# Altium schematic sheet A-Headers.SchDoc(A-Headers)
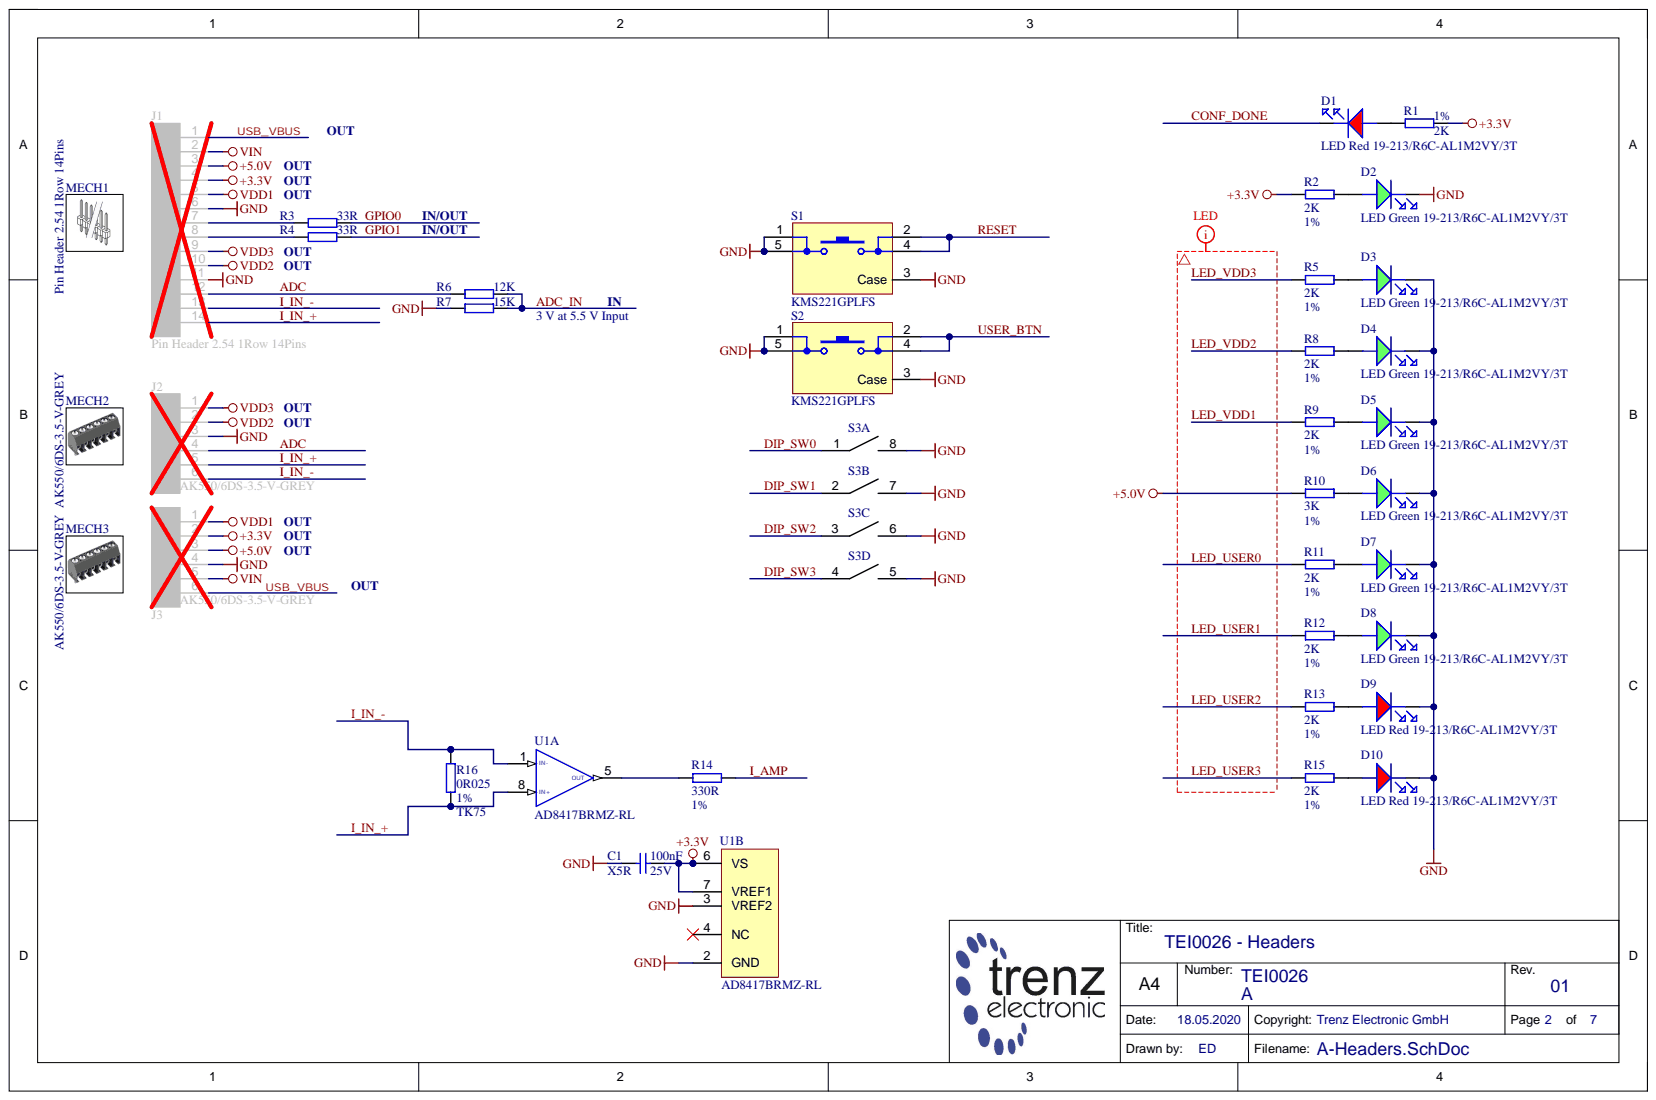
[diagram p0: A-Headers.SchDoc]

## Parts
C1  100nF  Technology: X5R  Voltage: 25V  [CAPC1005X55N]
D1  LED Red 19-213/R6C-AL1M2VY/3T  Database Table Name: LED  H-ART: 19-213/R6C-AL1M2VY/3T  [LEDC1608X55N_RED]
D2  LED Green 19-213/R6C-AL1M2VY/3T  Database Table Name: LED  [LEDC1608X55N_GREEN]
D3  LED Green 19-213/R6C-AL1M2VY/3T  Database Table Name: LED  [LEDC1608X55N_GREEN]
D4  LED Green 19-213/R6C-AL1M2VY/3T  Database Table Name: LED  [LEDC1608X55N_GREEN]
D5  LED Green 19-213/R6C-AL1M2VY/3T  Database Table Name: LED  [LEDC1608X55N_GREEN]
D6  LED Green 19-213/R6C-AL1M2VY/3T  Database Table Name: LED  [LEDC1608X55N_GREEN]
D7  LED Green 19-213/R6C-AL1M2VY/3T  Database Table Name: LED  [LEDC1608X55N_GREEN]
D8  LED Green 19-213/R6C-AL1M2VY/3T  Database Table Name: LED  [LEDC1608X55N_GREEN]
D9  LED Red 19-213/R6C-AL1M2VY/3T  Database Table Name: LED  H-ART: 19-213/R6C-AL1M2VY/3T  [LEDC1608X55N_RED]
D10  LED Red 19-213/R6C-AL1M2VY/3T  Database Table Name: LED  H-ART: 19-213/R6C-AL1M2VY/3T  [LEDC1608X55N_RED]
J1  Pin Header 2.54 1Row 14Pins  [STIFTLEISTE2_54 OG 1R-14]
J2  AK550/6DS-3.5-V-GREY  [AK550-6DS-3.5-V-GRAU]
J3  AK550/6DS-3.5-V-GREY  [AK550-6DS-3.5-V-GRAU]
MECH1  Pin Header 2.54 1Row 14Pins  (mechanical)
MECH2  AK550/6DS-3.5-V-GREY  (mechanical)
MECH3  AK550/6DS-3.5-V-GREY  (mechanical)
R1  2K  Tolerance: 1%  [RESC1005X40N]
R2  2K  Tolerance: 1%  [RESC1005X40N]
R3  33R  [RESC1005X40N]
R4  33R  [RESC1005X40N]
R5  2K  Tolerance: 1%  [RESC1005X40N]
R6  12K  [RESC1005X40N]
R7  15K  [RESC1005X40N]
R8  2K  Tolerance: 1%  [RESC1005X40N]
R9  2K  Tolerance: 1%  [RESC1005X40N]
R10  3K  Tolerance: 1%  [RESC1005X40N]
R11  2K  Tolerance: 1%  [RESC1005X40N]
R12  2K  Tolerance: 1%  [RESC1005X40N]
R13  2K  Tolerance: 1%  [RESC1005X40N]
R14  330R  Tolerance: 1%  [RESC1005X40N]
R15  2K  Tolerance: 1%  [RESC1005X40N]
R16  0R025  Technology: TK75  Tolerance: 1%  [RESC5025X88L50N]
S1  KMS221GPLFS  [KMS2xxGPLFS]
S2  KMS221GPLFS  [KMS2xxGPLFS]
S3  CHS-04TA  [CHS-04TA]  (value not drawn)  (parts A,B,C,D)
U1  AD8417BRMZ-RL  [SOP65P490X110-8N]  (parts A,B)

## Nets
+3.3V: C1.1 J1.4 J3.2 R1.2 R2.2 U1.6(VS) U1.7(VREF1)
+5.0V: J1.3 J3.3 R10.1
GND: C1.2 D2.K D3.K D4.K D5.K D6.K D7.K D8.K D9.K D10.K J1.6 J1.11 J2.3 J3.4 R7.1 S1.1 S1.3(Case) S1.5 S2.1 S2.3(Case) S2.5 S3.5 S3.6 S3.7 S3.8 U1.2(GND) U1.3(VREF2)
VDD1: J1.5 J3.1
VDD2: J1.10 J2.2
VDD3: J1.9 J2.1
VIN: J1.2 J3.5
ADC: J1.12 J2.4 R6.1
ADC_IN: R6.2 R7.2
CONF_DONE: D1.K
DIP_SW0: S3.1
DIP_SW1: S3.2
DIP_SW2: S3.3
DIP_SW3: S3.4
GPIO0: R3.2
GPIO1: R4.2
I_AMP: R14.2
I_IN_+: J1.14 J2.5 R16.2 U1.8(IN+)
I_IN_-: J1.13 J2.6 R16.1 U1.1(IN-)
LED_USER0: R11.1
LED_USER1: R12.1
LED_USER2: R13.1
LED_USER3: R15.1
LED_VDD1: R9.1
LED_VDD2: R8.1
LED_VDD3: R5.1
RESET: S1.2 S1.4
USB_VBUS: J1.1 J3.6
USER_BTN: S2.2 S2.4
NetD1_A: D1.A R1.1
NetD2_A: D2.A R2.1
NetD3_A: D3.A R5.2
NetD4_A: D4.A R8.2
NetD5_A: D5.A R9.2
NetD6_A: D6.A R10.2
NetD7_A: D7.A R11.2
NetD8_A: D8.A R12.2
NetD9_A: D9.A R13.2
NetD10_A: D10.A R15.2
NetJ1_7: J1.7 R3.1
NetJ1_8: J1.8 R4.1
NetR14_1: R14.1 U1.5(OUT)

Not connected: U1 4(NC)

## Library data of the parts
C1 = 22472: KON 100nF 25V X5R ±10% SMD BF 1005 (0402); Database Table Name: C_1005_0402; Mixed: SMT; Preferred: Yes; Temperature-Range: -55/+85; Value: 100n; AN: 22472; Case: 1005 (0402); Component Kind: Standard; Library Name: TE.DbLib
D1 D9 D10 = 28261: Chip LED 0603 Briliant Red; Mixed: SMT; AN: 28261; Case: 1608 (0603); Component Kind: Standard; Library Name: TE.DbLib; HelpURL: b:\AD\adlib\datasheet\19-213-R6C\
D2-D8 = 28262: Chip LED 0603 Briliant Green; H-ART: 19-213/G6C-BM1N2/DT; Mixed: SMT; Temperature-Range: -40/+85 (Abs); AN: 28262; Case: 1608 (0603); Component Kind: Standard; Library Name: TE.DbLib; HelpURL: b:\AD\adlib\datasheet\19-213-G6C-BM1\
J1 = 27961: Stiftleiste gerade 1-reihig, 14pol.; Database Table Name: CON_HEADER; Mixed: THT; AN: 27961; Component Kind: Standard; Library Name: TE.DbLib; HelpURL: b:\AD\adlib\datasheet\W+P_943_944_945\
J2 J3 = 30193: Database Table Name: CON_PWR; H-ART: 50550060121G; Mixed: THT; Temperature-Range: -40/+105; AN: 30193; Component Kind: Standard; Description: AK550/6DS-3.5-V-GREY; Library Name: TE.DbLib; Storage-Temperature: -40/+105; HelpURL: b:\AD\adlib\datasheet\PTR\AK550xxDS_3,5_V\
MECH1 = 30273: Stiftleiste gerade 1-reihig, 14pol.; Database Table Name: CON_HEADER; Extra: 2,54mm RM, 11,3mm Stiftlänge; Mixed: THT; Trenz-Article-Number: 27961; AN: 30273; Library Name: TE.DbLib; HelpURL: b:\AD\adlib\datasheet\W+P_943_944_945\
MECH2 MECH3 = 30274: Database Table Name: CON_MATE; Extra: 6 Position Wire to Board Terminal Block Horizontal with Board 0.138" (3.5mm) THT; H-ART: 50550060121G; Trenz-Article-Number: 30193; AN: 30274; Description: AK550/6DS-3.5-V-GREY; Library Name: TE.DbLib; HelpURL: b:\AD\adlib\datasheet\PTR\AK550xxDS_3,5_V\
R1 R2 R5 R8 R9 R11-R13 R15 = 25073: WID SMD 2K 1% 0,063W  BF 1005 (0402); Database Table Name: R_1005_0402; H-ART: RC0402FR-072KL; Mixed: SMT; Preferred: Yes; AN: 25073; Case: 1005 (0402); Component Kind: Standard; Library Name: TE.DbLib; Technology: TK100; Value: 2K
R3 R4 = 22770: WID SMD 33R 1% 0,063W BF 1005 (0402); Database Table Name: R_1005_0402; H-ART: RC0402FR-0733RL; Mixed: SMT; Preferred: Yes; Value: 33; AN: 22770; Case: 1005 (0402); Component Kind: Standard; Library Name: TE.DbLib; Technology: TK100; Tolerance: 1%
R6 = 22598: WID SMD 12K 1% 0,063W  BF 1005 (0402); Database Table Name: R_1005_0402; Mixed: SMT; Preferred: Yes; AN: 22598; Case: 1005 (0402); Component Kind: Standard; Library Name: TE.DbLib; Technology: TK100; Tolerance: 1%; Value: 12K
R7 = 24870: WID SMD 15K 1% 0,063W BF 1005 (0402); Database Table Name: R_1005_0402; Mixed: SMT; Preferred: Yes; AN: 24870; Case: 1005 (0402); Component Kind: Standard; Library Name: TE.DbLib; Technology: TK100; Tolerance: 1%; Value: 15K
R10 = 23132: WID SMD 3K 1% 0,063W BF 1005 (0402); Database Table Name: R_1005_0402; Mixed: SMT; AN: 23132; Case: 1005 (0402); Component Kind: Standard; Library Name: TE.DbLib; Technology: TK100; Value: 3K
R14 = 22762: WID SMD 330R 1% 0,063W  BF 1005 (0402); Database Table Name: R_1005_0402; H-ART: RC0402FR-13330RL; Mixed: SMT; Preferred: Yes; Value: 330; AN: 22762; Case: 1005 (0402); Component Kind: Standard; Library Name: TE.DbLib; Technology: TK100
R16 = 30277: WID SMD 0.025R 0.5W 1% AEC-Q200  BF 5025 (2010); Database Table Name: A_R_SMD; H-ART: WSL2010R0250FEA; Mixed: SMT; Temperature-Range: -65/+170; Value: 0.025; AN: 30277; Case: 5025 (2010); Component Kind: Standard; Library Name: TE.DbLib; Voltage: 0.5W; HelpURL: b:\AD\adlib\datasheet\Vishay\WSL\
S1 S2 = 28549: Database Table Name: PB_SW; Mixed: SMT; AN: 28549; Component Kind: Standard; Description: KMS221GPLFS; H-ART: KMS221GPLFS; Library Name: TE.DbLib; HelpURL: B:\AD\adlib\datasheet\KMS
S3 = 23417: DIP Schalter 4 fach 1,27mm SMD; Database Table Name: PB_SW; Mixed: SMT; Preferred: Yes; AN: 23417; Component Kind: Standard; H-ART: CHS-04TA; Library Name: TE.DbLib; Trenz-Stock: Yes; HelpURL: B:\AD\adlib\datasheet\CHS
U1 = 30205: Database Table Name: OP; Mixed: SMT; Temperature-Range: -40/+125; AN: 30205; Case: MSOP8; Component Kind: Standard; Description: AD8417BRMZ-RL; H-ART: AD8417BRMZ-RL; Library Name: TE.DbLib; Storage-Temperature: -40/+125 (Tj); HelpURL: b:\AD\adlib\datasheet\AnalogDevices\AD8417\
